# Altium schematic sheet CLK_SW.SchDoc
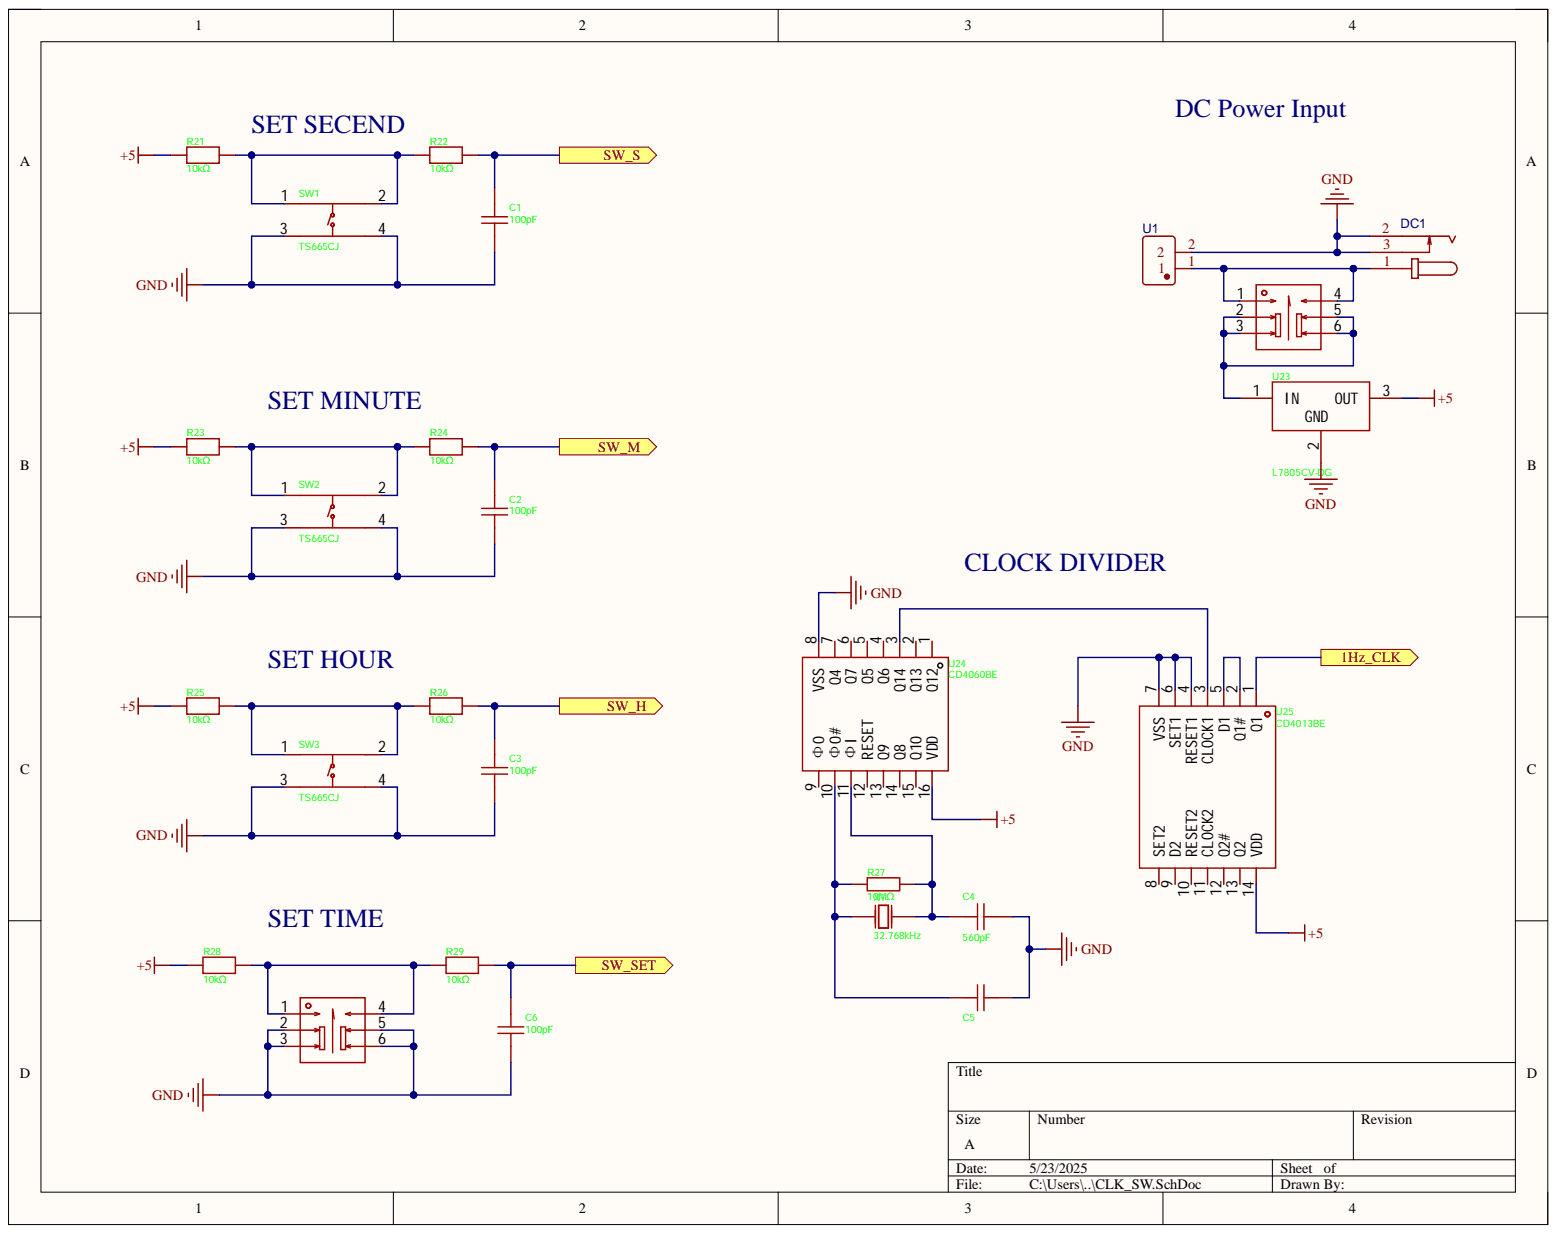
[diagram p0: CLK_SW.SchDoc]

## Parts
C1  100pF  [CAP-TH_L5.0-W3.0-P5.00-D0.6-S2.45]
C2  100pF  [CAP-TH_L5.0-W3.0-P5.00-D0.6-S2.45]
C3  100pF  [CAP-TH_L5.0-W3.0-P5.00-D0.6-S2.45]
C4  560pF  [CAP-TH_L8.5-W3.0-P5.00-D0.6-S2.5]
C5  22pF  [CAP-TH_L7.4-W4.0-P6.40-D0.5-S1.00]  (value not drawn)
C6  100pF  [CAP-TH_L5.0-W3.0-P5.00-D0.6-S2.45]
DC1  DC-005-2.5A-2.0  [CONN-TH_DC-005-2.5A-2.0]  (value not drawn)
R21  10kΩ  [RES-TH_BD2.4-L6.3-P10.30-D0.6]
R22  10kΩ  [RES-TH_BD2.4-L6.3-P10.30-D0.6]
R23  10kΩ  [RES-TH_BD2.4-L6.3-P10.30-D0.6]
R24  10kΩ  [RES-TH_BD2.4-L6.3-P10.30-D0.6]
R25  10kΩ  [RES-TH_BD2.4-L6.3-P10.30-D0.6]
R26  10kΩ  [RES-TH_BD2.4-L6.3-P10.30-D0.6]
R27  10MΩ  [RES-TH_BD2.2-L6.5-P10.50-D0.6]
R28  10kΩ  [RES-TH_BD2.4-L6.3-P10.30-D0.6]
R29  10kΩ  [RES-TH_BD2.4-L6.3-P10.30-D0.6]
SW1  TS665CJ  [SW-TH_4P-L6.0-W6.0-P4.50-LS6.5]
SW2  TS665CJ  [SW-TH_4P-L6.0-W6.0-P4.50-LS6.5]
SW3  TS665CJ  [SW-TH_4P-L6.0-W6.0-P4.50-LS6.5]
U1  DBT50G-7.62-2P  [CONN-TH_2P-P7.62_L15.2-W16.7-EX4.2]  (value not drawn)
U22  PS-7054DVB-6PL  [SW-TH_6P-L7.0-W7.0-P2.00]  (value not drawn)
U23  L7805CV-DG  [TO-220-3_L10.0-W4.5-P2.54-L]
U24  CD4060BE  [DIP-16_L19.8-W6.5-P2.54-LS7.6-BL]
U25  CD4013BE  [DIP-14_L19.2-W6.6-P2.54-LS10.9-BL]
U26  PS-7054DVB-6PL  [SW-TH_6P-L7.0-W7.0-P2.00]  (value not drawn)
X1  32.768kHz  [OSC-TH_BD3.1-P0.8-D0.3]

## Ports
1Hz_CLK, SW_H, SW_M, SW_S, SW_SET

## Nets
+5: R21.2 R23.2 R25.2 R28.2 U23.3 U24.16(VDD) U25.14(VDD)
GND: C1.1 C2.1 C3.1 C4.1 C5.1 C6.1 DC1.2 DC1.3 SW1.3 SW1.4 SW2.3 SW2.4 SW3.3 SW3.4 U1.2 U23.2 U24.8(VSS) U25.4(RESET1) U25.6(SET1) U25.7(VSS) U26.2 U26.3 U26.5 U26.6
1Hz_CLK: U25.1(Q1) ; port 1Hz_CLK
SW_H: C3.2 R26.1 ; port SW_H
SW_M: C2.2 R24.1 ; port SW_M
SW_S: C1.2 R22.1 ; port SW_S
SW_SET: C6.2 R29.1 ; port SW_SET
NetC4_2: C4.2 R27.1 U24.11(ΦI) X1.2
NetC5_2: C5.2 R27.2 U24.10(Φ0#) X1.1
NetDC1_1: DC1.1 U1.1 U22.1 U22.4
NetR21_1: R21.1 R22.2 SW1.1 SW1.2
NetR23_1: R23.1 R24.2 SW2.1 SW2.2
NetR25_1: R25.1 R26.2 SW3.1 SW3.2
NetR28_1: R28.1 R29.2 U26.1 U26.4
NetU22_2: U22.2 U22.3 U22.5 U22.6 U23.1
NetU24_3: U24.3(Q14) U25.3(CLOCK1)
NetU25_2: U25.2(Q1#) U25.5(D1)

Not connected: U24 1(Q12) 2(Q13) 4(Q6) 5(Q5) 6(Q7) 7(Q4) 9(Φ0) 12(RESET) 13(Q9) 14(Q8) 15(Q10); U25 8(SET2) 9(D2) 10(RESET2) 11(CLOCK2) 12(Q2#) 13(Q2)

## Library data of the parts
C1-C3 C6 = Cap_100pF_50V_ceramic: Manufacturer: SHM(南方宏明); Add into BOM: yes; Device: DCT101K20Y5PF6FJ5A0; Pin Spacing: 5mm; Temperature Coefficient: Y5P; Tolerance: ±10%; Voltage Rating: 50V; Component Kind: Standard; Convert to PCB: yes; Datasheet: https://atta.szlcsc.com/upload/public/pdf/source/20210929/C4FEE90C41821237A84737C21615A4EC.pdf; JLCPCB Part Class: Extended Part; LCSC Part Name: 100pF ±10% 50V; Manufacturer Part: DCT101K20Y5PF6FJ5A0; PCB3D: CAP-TH_L5.0-W3.0-P5.00-D0.6-S2.45; Supplier: LCSC; Supplier Footprint: 插件,P=5mm; Supplier Part: C2896026; Symbol: DCT101K20Y5PF6FJ5A0; Value: 100pF; Library Name: BCD_Clock_SCH_lib.SchLib
C4 = Cap_560pF_50V_ceraic: Manufacturer: SHM(南方宏明); Add into BOM: yes; Device: DCC561J34SL F6FJ5A0; Pin Spacing: 5mm; Temperature Coefficient: SL; Tolerance: ±5%; Voltage Rating: 50V; Component Kind: Standard; Convert to PCB: yes; Datasheet: https://atta.szlcsc.com/upload/public/pdf/source/20210929/2675BE423E96B4772DBF330AE545E239.pdf; JLCPCB Part Class: Extended Part; LCSC Part Name: 560pF ±5% 50V; Manufacturer Part: DCC561J34SL F6FJ5A0; PCB3D: CAP-TH_L8.5-W3.0-P5.00-D0.6-S2.5; Supplier: LCSC; Supplier Footprint: 插件,P=5mm; Supplier Part: C2896138; Symbol: DCC561J34SL F6FJ5A0; Value: 560pF; Library Name: BCD_Clock_SCH_lib.SchLib
C5 = Cap_22pF_50V_ceramic: Manufacturer: Dersonic(德尔创); Add into BOM: yes; Body Thickness: 2.4mm; Device: CC1H220KA1EDCH4B1100; Pin Spacing: 2.54mm; Temperature Coefficient: NP0; Tolerance: ±10%; Voltage Rating: 50V; Component Kind: Standard; Convert to PCB: yes; Datasheet: https://atta.szlcsc.com/upload/public/pdf/source/20211103/062C09467AB58979163D97626BAD0297.pdf; Diameter: 4.8mm; JLCPCB Part Class: Extended Part; LCSC Part Name: 22pF ±10% 50V; Manufacturer Part: CC1H220KA1EDCH4B1100; Supplier: LCSC; Supplier Footprint: 插件,P=2.54mm; Supplier Part: C2832510; Symbol: CC1H220KA1EDCH4B1100; Value: 22pF; Library Name: BCD_Clock_SCH_lib.SchLib
DC1 = DC-005-2.5A-2.0: Manufacturer: XKB Connection(中国星坤); Contributor: LCSC; Component Kind: Standard; JLCPCB Part Class: Extended Part; Manufacturer Part: DC-005-2.5A-2.0; spicePre: D; spiceSymbolName: DC-005-2.5A-2.0; Supplier: LCSC; Supplier Part: C319099; Library Name: BCD_Clock_SCH_lib.SchLib
R21-R26 R28 R29 = Reg_10k_0.25W: Manufacturer: Tyohm(幸亚电阻); Add into BOM: yes; Device: RN1/4W10KFT/BA1; Overload Voltage (Max): 250V; Power(Watts): 250mW; Symbol: RN 1/4W 10K F T/B A1; Temperature Coefficient: ±50ppm/℃; Tolerance: ±1%; Component Kind: Standard; Convert to PCB: yes; Datasheet: https://atta.szlcsc.com/upload/public/pdf/source/20190808/C410699_D126E64D28B638F1D73B58E9F61DFD9B.pdf; JLCPCB Part Class: Extended Part; LCSC Part Name: 10kΩ ±1% 250mW 金属膜电阻; Manufacturer Part: RN1/4W10KFT/BA1; Operating Temperature Range: -55℃~+125℃; PCB3D: RES-TH_BD2.4-L6.3-P10.30-D0.6; Supplier: LCSC; Supplier Footprint: 插件,D2.4xL6.5mm; Supplier Part: C410695; Type: Metal Film Resistors; Value: 10kΩ; Library Name: BCD_Clock_SCH_lib.SchLib
R27 = Reg_10M_0.25W: Manufacturer: CCO(千志电子); Add into BOM: yes; Device: CF1/4W-10MΩ±5% T; Power(Watts): 250mW; Symbol: CF1/4W-10MΩ±5%; Temperature Coefficient: -1500ppm/℃~0ppm/℃; Tolerance: ±5%; Voltage-Supply(Max): 250V; Component Kind: Standard; Convert to PCB: yes; Datasheet: https://atta.szlcsc.com/upload/public/pdf/source/20190910/C427328_A0A2D65F3FD547937F4E674C90868175.pdf; JLCPCB Part Class: Extended Part; LCSC Part Name: 10MΩ ±5% 250mW 碳膜电阻; Manufacturer Part: CF1/4W-10MΩ±5% T; Operating Temperature: -55℃~+155℃; PCB3D: RES-TH_BD2.2-L6.5-P10.50-D0.6; Supplier: LCSC; Supplier Footprint: 插件,D2.3xL6.5mm; Supplier Part: C127261; Type: Carbon Resister; Value: 10MΩ; Library Name: BCD_Clock_SCH_lib.SchLib
SW1-SW3 = TS665CJ: Manufacturer: SHOU HAN(首韩); Actuator Style: Round Button; Add into BOM: yes; Circuit: SPST; Contact Current: 50mA; Switch Height: 5mm; Switch Length: 6mm; Voltage Rating (DC): 12V; Component Kind: Standard; Convert to PCB: yes; Datasheet: https://atta.szlcsc.com/upload/public/pdf/source/20190521/C393938_BDABEAC600B4C55E200A80AA129B70BC.pdf; Device: TS665CJ; JLCPCB Part Class: Extended Part; LCSC Part Name: 6*6*5mm 直插 轻触开关; Manufacturer Part: TS665CJ; Mechanical Life: -; Mounting Style: Straight; PCB3D: SW-TH_4P-L6.0-W6.0-H5.0-LS6.5-P4.50; Supplier: LCSC; Supplier Footprint: 插件; Supplier Part: C393938; Switch Width: 6mm; Symbol: TS665CJ; With Lamp: -; Library Name: BCD_Clock_SCH_lib.SchLib
U1 = DBT50G-7.62-2P: Manufacturer: DORABO(地博电气); Manufacturer Part: DBT50G-7.62-2P-BK-P; Contributor: LCSC; Component Kind: Standard; JLCPCB Part Class: Extended Part; spicePre: U; spiceSymbolName: DBT50G-7.62-2P; Supplier: LCSC; Supplier Part: C496127; Library Name: BCD_Clock_SCH_lib.SchLib
U22 U26 = PS-7054DVB-6PL: Manufacturer: G-Switch(品赞); Add into BOM: yes; Operating Force: 250gf; Self Lock / No Lock: LATCHING; Component Kind: Standard; Convert to PCB: yes; Datasheet: https://atta.szlcsc.com/upload/public/pdf/source/20240319/F2B7FB2723231C6F1D5F9BB35EBA5342.pdf; Device: PS-7054DVB-6PL; JLCPCB Part Class: Extended Part; LCSC Part Name: 7*7自锁按键开关; Manufacturer Part: PS-7054DVB-6PL; PCB3D: SW-TH_6P-L7.0-W7.0-P2.00; Supplier: LCSC; Supplier Footprint: 插件-6P,7x7mm; Supplier Part: C22363819; Symbol: PS-7054DVB-6PL; Library Name: BCD_Clock_SCH_lib.SchLib
U23 = L7805CV-DG: Manufacturer: ST(意法半导体); Add into BOM: yes; Dropout Voltage: 2V@(1A); Maximum Input Voltage: 35V; Number of Outputs: 1; Output Current: 1.5A; Output Polarity: Positive electrode; Output Type: Fixed; Output Voltage: 5V; Power Supply Rejection Ratio (PSRR): 62dB@(120Hz); standby current: 8mA; Component Kind: Standard; Convert to PCB: yes; Datasheet: https://atta.szlcsc.com/upload/public/pdf/source/20140724/1457707163191.pdf; Device: L7805CV-DG; Feature: Short-Circuit  Protection；Thermal Protection(TSD)；Overcurrent Protection(OCP); JLCPCB Part Class: Extended Part; LCSC Part Name: 正稳压器IC; Manufacturer Part: L7805CV-DG; Operating Temperature: 0℃~+125℃; PCB3D: TO-220-3_L10.0-W4.5-P2.54-L; Supplier: LCSC; Supplier Footprint: TO-220; Supplier Part: C3795; Symbol: L7805CV-DG; Library Name: BCD_Clock_SCH_lib.SchLib
U24 = CD4060BE: Manufacturer: HGSEMI(华冠); Add into BOM: yes; Symbol: CD4060BE_C507159; Component Kind: Standard; Convert to PCB: yes; Datasheet: https://atta.szlcsc.com/upload/public/pdf/source/20200730/C507159_CD40804D6EEA04930EB86D9D2459BFCA.pdf; Device: CD4060BE; JLCPCB Part Class: Extended Part; LCSC Part Name: CMOS 14 级纹波进位二进制计数器/除法器和振荡器; Manufacturer Part: CD4060BE; PCB3D: DIP-16_L20.0-W6.4-H3.5-LS7.62-P2.54; Supplier: LCSC; Supplier Footprint: DIP-16; Supplier Part: C507159; Library Name: BCD_Clock_SCH_lib.SchLib
U25 = CD4013BE: Manufacturer: TI(德州仪器); Add into BOM: yes; Clock Frequency: 24MHz; Current - Output High(IOH): 6.8mA; Function: Positioning and resetting; Input Capacitance: 5pF; Number of Bits per Element: 1; Number of Elements: 2; Output Type: Complementary Type; Propagation Delay: 90ns@15V,50pF; Quiescent Current: 4uA; Trigger Type: Rising Edge; Voltage - Supply: 3V~18V; Component Kind: Standard; Convert to PCB: yes; Current - Output Low(IOL): 6.8mA; Datasheet: https://www.ti.com/cn/lit/gpn/cd4013b; Device: CD4013BE; JLCPCB Part Class: Extended Part; LCSC Part Name: CD4013BE; Manufacturer Part: CD4013BE; Operating Temperature: -55℃~+125℃; PCB3D: DIP-14_L20.0-W6.7-H4.1-LS7.62-P2.54; Series: 4000BSeries; Supplier: LCSC; Supplier Footprint: DIP-14; Supplier Part: C5199; Symbol: CD4013BE; Type: D-Type; Library Name: BCD_Clock_SCH_lib.SchLib
X1 = AB38T-32.768KHZ: Manufacturer: ABRACON; Add into BOM: yes; Equivalent Series Resistance (ESR): 30kΩ; Normal temperature Frequency Tolerance: ±20ppm; Component Kind: Standard; Convert to PCB: yes; Crystal Type: -; Datasheet: https://atta.szlcsc.com/upload/public/pdf/source/20180613/C194002_28532E064E51A8E79CA51D5C8B24387E.pdf; Device: AB38T-32.768KHZ; Frequency Stability(Full temperature range): -; JLCPCB Part Class: Extended Part; LCSC Part Name: 32.768kHz ±20ppm 12.5pF; Manufacturer Part: AB38T-32.768KHZ; PCB3D: OSC-TH_D3.0-W8.0-P0.8; Supplier: LCSC; Supplier Footprint: DT-38; Supplier Part: C194002; Symbol: AB38T-32.768KHZ; Value: 32.768kHz; Library Name: BCD_Clock_SCH_lib.SchLib
